# Altium schematic sheet Programmer.SchDoc
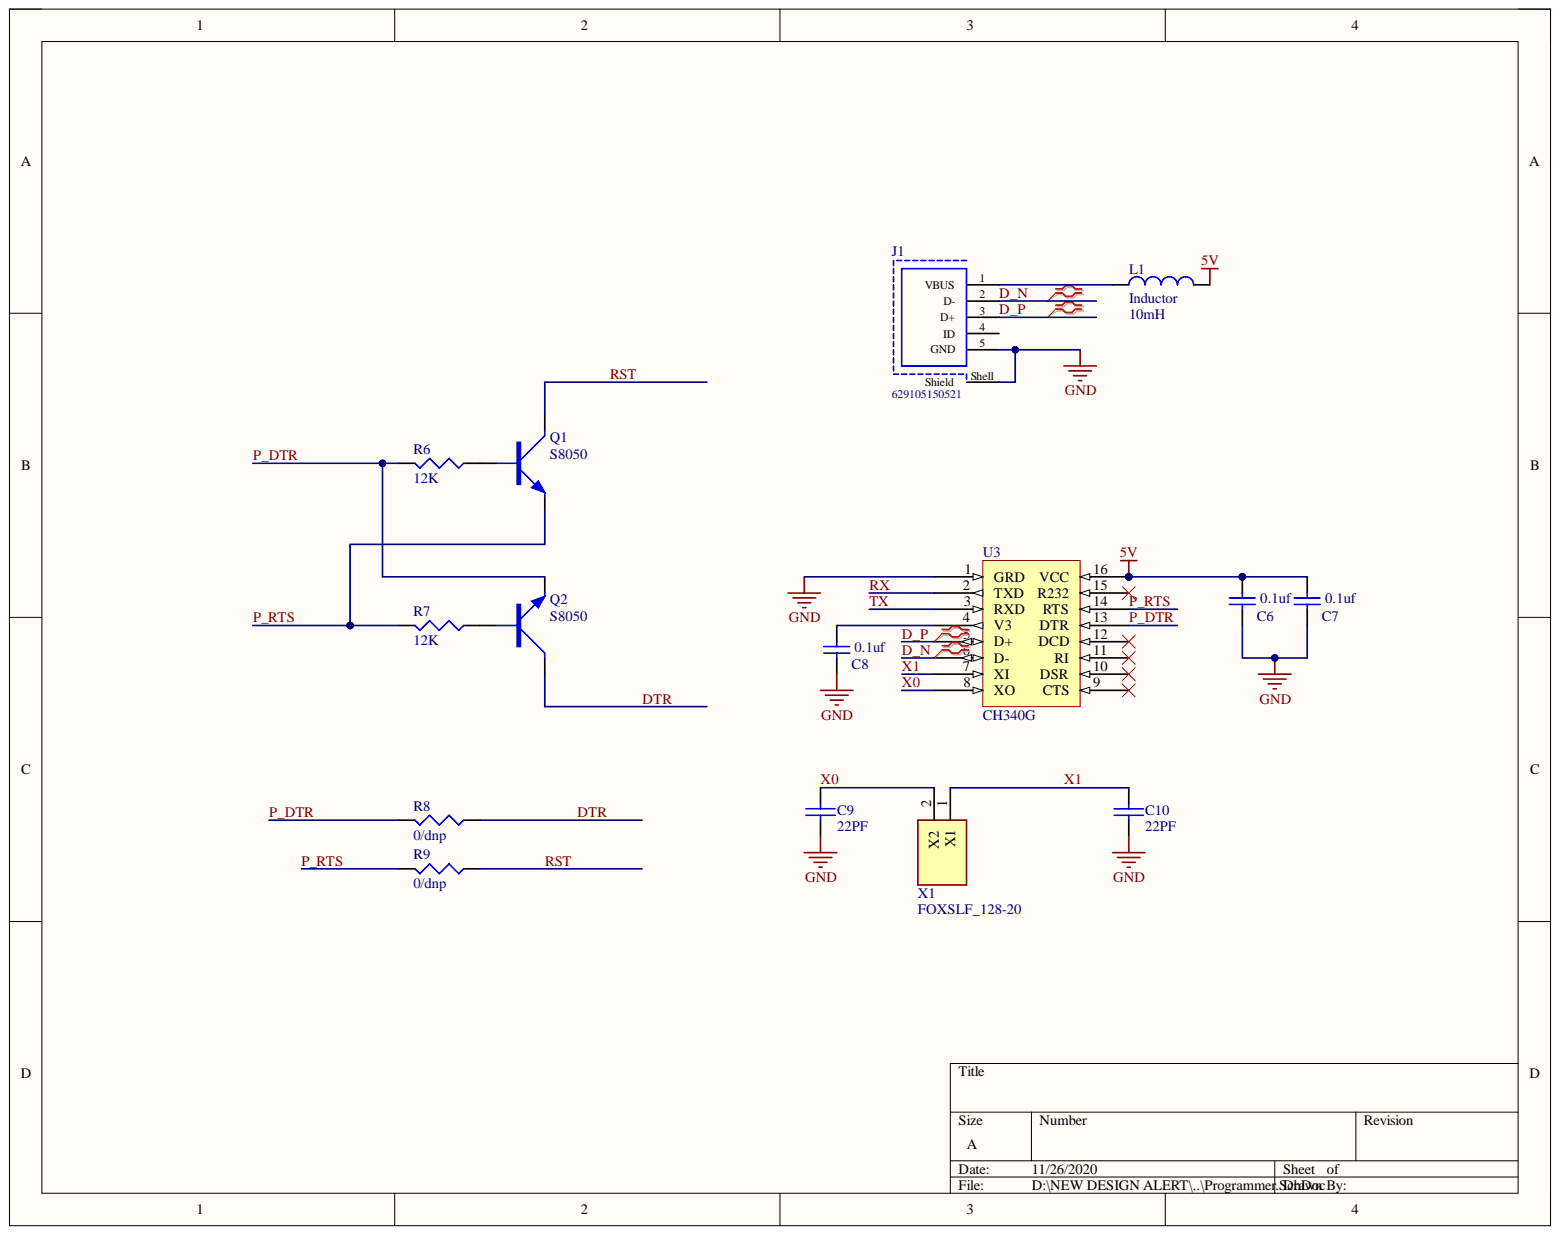
[diagram p0: Programmer.SchDoc]

## Parts
C6  0.1uf  [CAPC0603]
C7  0.1uf  [CAPC0603]
C8  0.1uf  [CAPC0603]
C9  22PF  [CAPC0603]
C10  22PF  [CAPC0603]
J1  629105150521  [HRS_ZX62D-B-5P8]
L1  Inductor  value 10mH  [CAPC0805]
Q1  S8050  [SOT23-3]
Q2  S8050  [SOT23-3]
R6  12K  [RESC0603]
R7  12K  [RESC0603]
R8  0/dnp  [RESC0603]
R9  0/dnp  [RESC0603]
U3  CH340G  [SOIC16_N]
X1  FOXSLF_128-20  [FOXSLF12820]

## Nets
5V: C6.1 C7.1 L1.2 U3.16(VCC)
GND: C6.2 C7.2 C8.2 C9.1 C10.1 J1.5(GND) J1.Shell(Shield) U3.1(GRD)
D_N: J1.2(D-) U3.6(D-)
D_P: J1.3(D+) U3.5(D+)
DTR: Q2.3 R8.1
P_DTR: Q2.2 R6.2 R8.2 U3.13(DTR)
P_RTS: Q1.2 R7.2 R9.2 U3.14(RTS)
RST: Q1.3 R9.1
RX: U3.2(TXD)
TX: U3.3(RXD)
X0: C9.2 U3.8(XO) X1.2
X1: C10.2 U3.7(XI) X1.1
NetC8_1: C8.1 U3.4(V3)
NetJ1_1: J1.1(VBUS) L1.1
NetQ1_1: Q1.1 R6.1
NetQ2_1: Q2.1 R7.1

Not connected: J1 4(ID); U3 9(CTS) 10(DSR) 11(RI) 12(DCD) 15(R232)

## Library data of the parts
C6-C8 = CMP-2007-04765-1: Manufacturer: AVX Interconnect / Elco; Part Number: 08055C104KAJ2A; Component Kind: Standard; Library Name: Miscellaneous Devices.IntLib
C9 C10 = CAP NP: Component Kind: Standard; Library Name: Devices.SchLib
J1 = CMP-1502-03252-1: Micro USB 2.0 Type B Receptacle WR-COM, Horizontal, SMT, with Pegs, High Current at Pin 1 & Pin 5; Manufacturer: Wurth Elektronik; Contact Resistance: 30mOhm; Rated Current: 3A; Working Voltage: 30VAC; Component Kind: Standard; Connector Type: MicroUSB2.0TypeB; Datasheet URL: https://katalog.we-online.de/em/datasheet/629105150521.pdf; Gender: Receptacle; Library Name: MAX98357AETE+.Library.IntLib; Manufacturer URL: http://www.we-online.de; Mounting Technology: SMT; Operating Temperature: -40to85degC; Orientation: Horizontal; Package Reference: 629105150521; Packaging: TapeandReel; Part Number: 629105150521; Publisher: WurthElektronik
L1 = Inductor: Code_IEC: 1005; Code_JEITA: 0402; Component Kind: Standard; Description: Inductor; LatestRevisionDate: 29-May-2009; LatestRevisionNote: IPC-7351 Footprint Added.; Library Name: Miscellaneous Devices.IntLib; PackageDescription: Chip Inductor; PackageReference: 0402-A; Published: 8-Jun-2000; Signal Integrity: Inductor; Simulation: INDUCTOR; Publisher: Altium Limited
Q1 Q2 = NPN BEC: Component Kind: Standard; Library Name: Devices.SchLib
R6-R9 = RES1: RESISTOR; Component Kind: Standard; Library Name: Devices.SchLib
X1 = FOXSLF_128-20: Crystal or Oscillator; Manufacturer_Name: Fox; Manufacturer_Part_Number: FOXSLF/128-20; Arrow Part Number: FOXSLF/128-20; Arrow Price/Stock: https://www.arrow.com/en/products/foxslf128-20/fox-electronics; Component Kind: Standard; Datasheet Link: https://componentsearchengine.com/Datasheets/2/FOXSLF_128-20.pdf; Height: 3.6mm; Mouser Part Number: 559-FOXS128-20-LF; Mouser Price/Stock: https://www.mouser.co.uk/ProductDetail/Fox/FOXSLF-128-20?qs=mzRxyRlhVdtRySSKq5R9SA%3D%3D
U3 = CH340G: Component Kind: Standard; Library Name: CH340.Schlib1.SchLib
